# Altium schematic sheet extBoard_sheet3_interrupt_generator.SchDoc
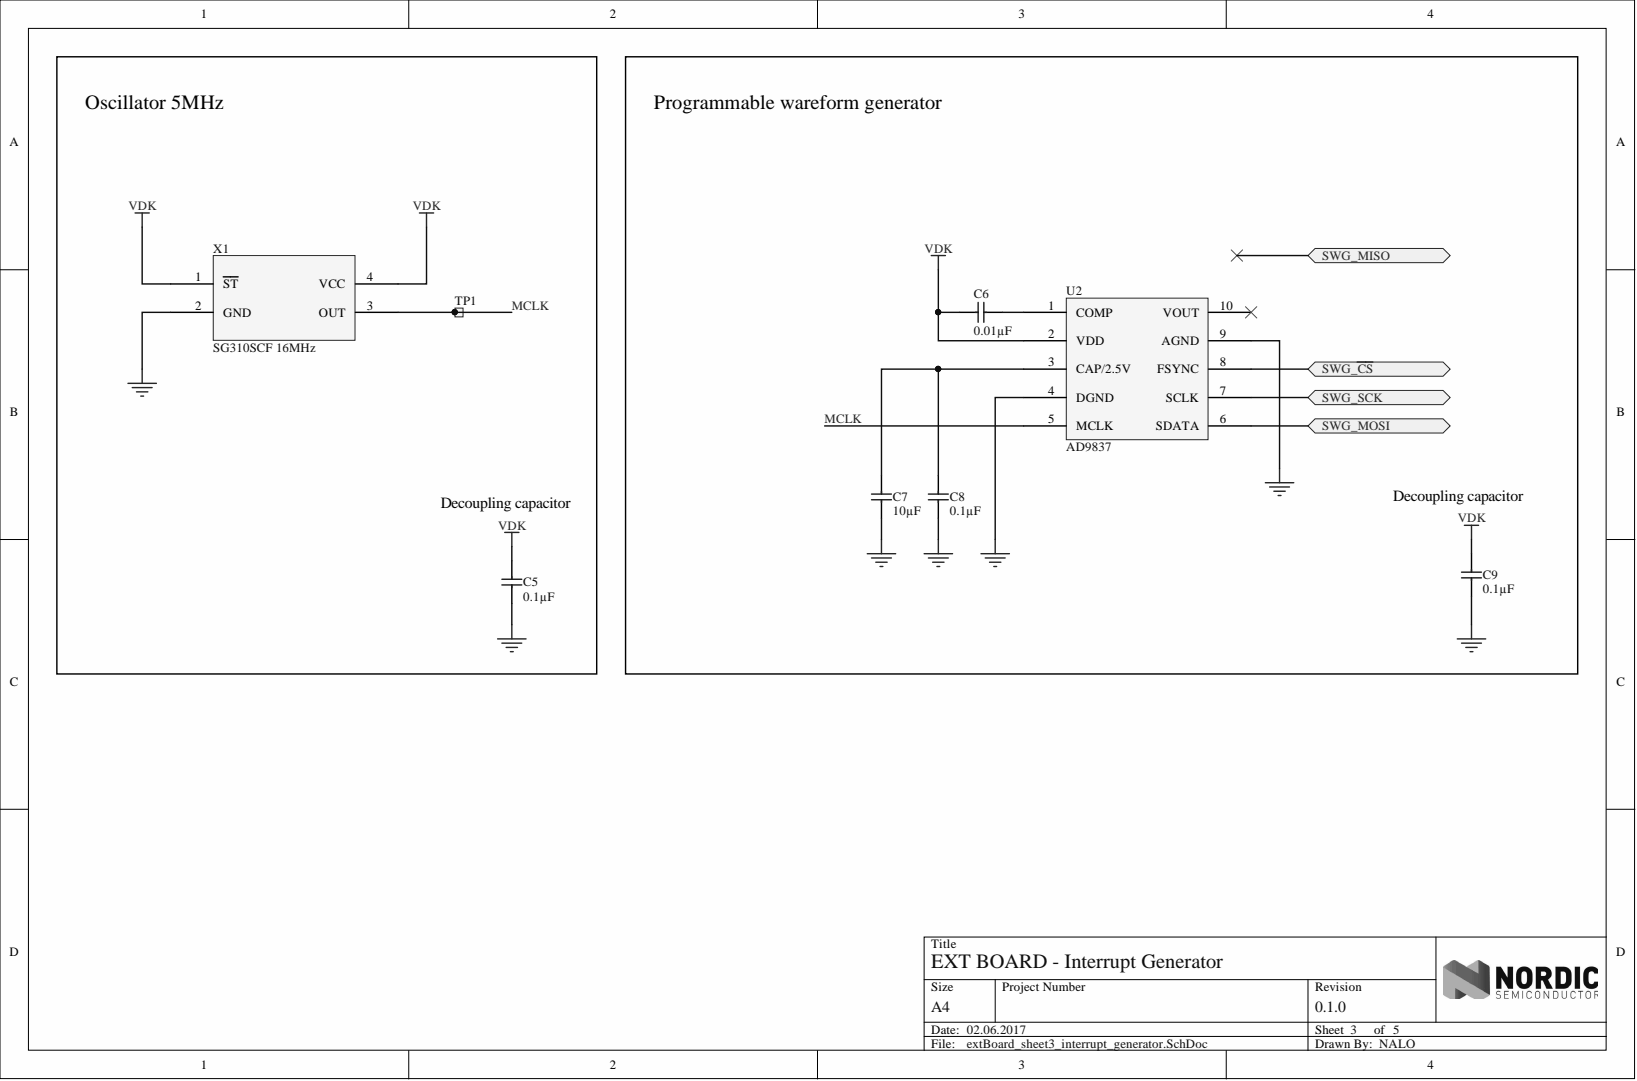
[diagram p0: extBoard_sheet3_interrupt_generator.SchDoc]

## Parts
C5  0.1µF  [CAPC2012X11L]
C6  0.01µF  [CAPC2012X11L]
C7  10µF  [CAPC2012X11L]
C8  0.1µF  [CAPC2012X11L]
C9  0.1µF  [CAPC2012X11L]
TP1  TP  [TESTPAD-TH]  (no BOM)
U2  AD9837  [DFN50P300X300X95-10N]
X1  SG310SCF 16MHz  value 16MHz  [SG310SCF]

## Ports
SWG_MISO, SWG_MOSI, SWG_SCK, SWG_~{CS}

## Nets
GND: C5.1 C7.1 C8.1 C9.1 U2.4(DGND) U2.9(AGND) X1.2(GND)
VDK: C5.2 C6.2 C9.2 U2.2(VDD) X1.1(ST) X1.4(VCC)
MCLK: TP1.1 U2.5(MCLK) X1.3(OUT)
SWG_~{CS}: U2.8(FSYNC) ; port SWG_~{CS}
SWG_MOSI: U2.6(SDATA) ; port SWG_MOSI
SWG_SCK: U2.7(SCLK) ; port SWG_SCK
NetC6_1: C6.1 U2.1(COMP)
NetC7_2: C7.2 C8.2 U2.3(CAP/2.5V)
SWG_MISO: ; port SWG_MISO

Not connected: U2 10(VOUT)

## Library data of the parts
C5-C9 = CAP_0805: Capacitor, X5R, ±10%; Part Number: C0805-4µ7; Artikkelnr Noca: 20039266; Artikkelnr Simpro: N.A.; Note: 16V; Component Kind: Standard; Footprint Doc: 0805; LatestRevisionDate: 2013-06-04; Library Name: pca10056.IntLib; Pin Count: 2; Published: 2009-08-21; Publisher: Nordic Semiconductor
  C5 C8 C9: Value: 0.1µF
  C6: Value: 0.01µF
  C7: Value: 10µF
TP1 = Testpoint TH: Testpoint, through hole; Artikkelnr Noca: N.A.; Artikkelnr Simpro: N.A.; Footprint Doc: TESTPAD-TH; LatestRevisionDate: 2009-12-12; Library Name: pca10056.IntLib; Part Number: Testpoint TH; Pin Count: 1; Published: 2009-12-12; Value: TP; Publisher: Nordic Semiconductor
X1 = SG310SCF: Component Kind: Standard; Library Name: extBoardLib.SchLib
U2 = AD9837: Component Kind: Standard; Library Name: extBoard.SchLib
